# Altium schematic sheet LM-008.SchDoc(LM-008)
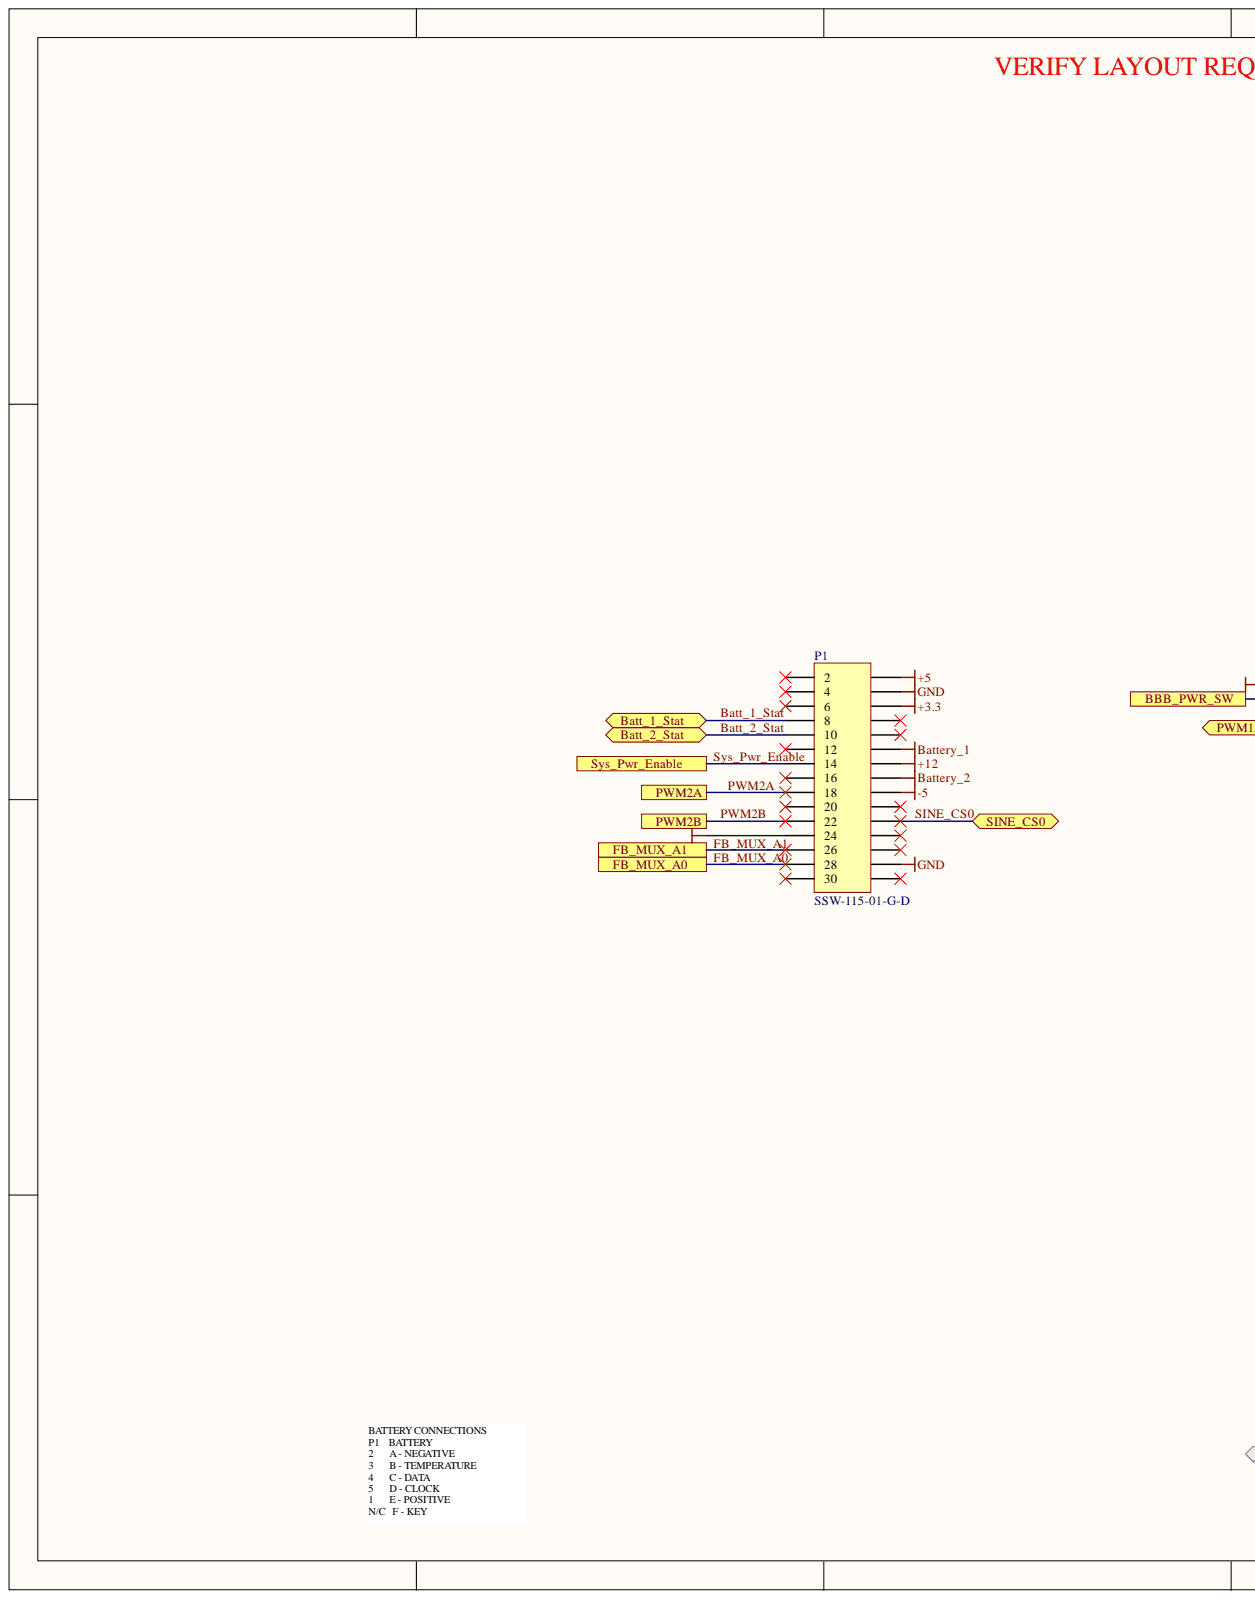
[diagram p0: LM-008.SchDoc, left]
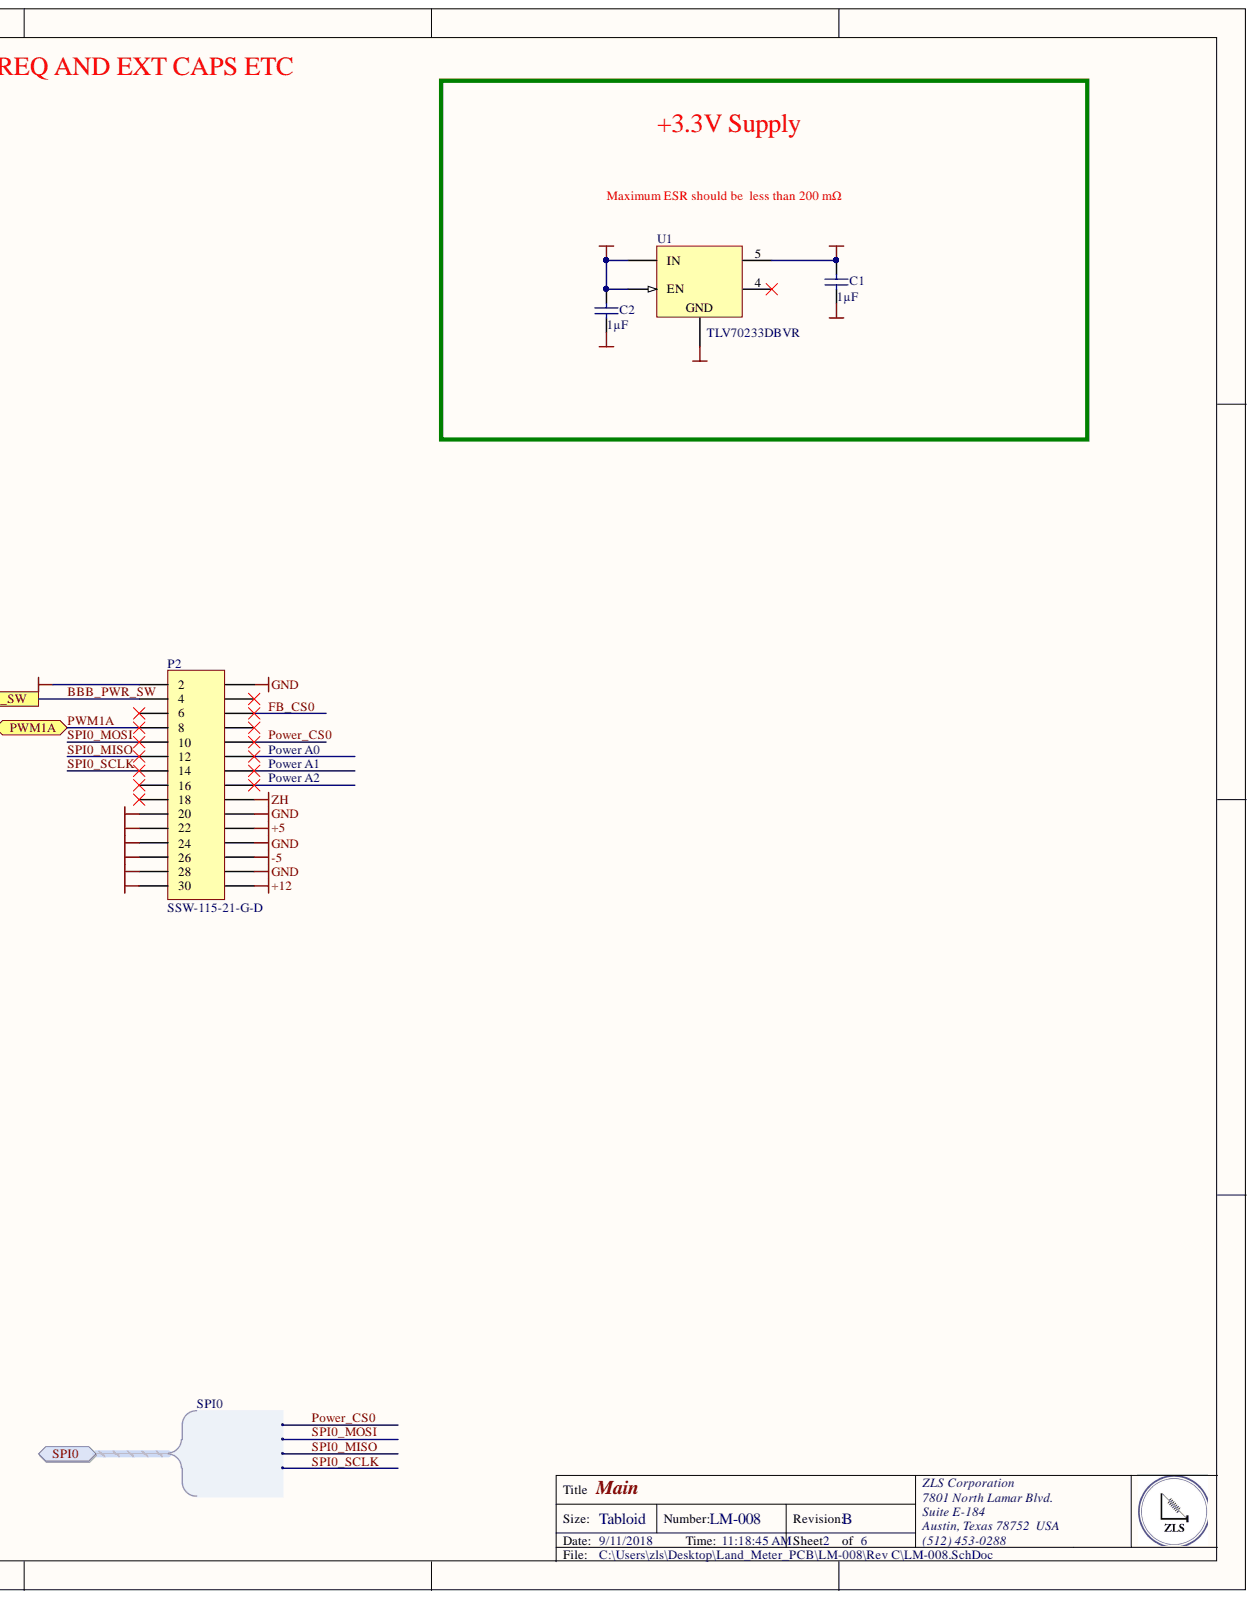
[diagram p1: LM-008.SchDoc, right]

## Parts
C1  Capacitance: 1µF  [CAPC1206(3216)130_N]  (hidden comment: CAP CER 1UF 50V X7R 1210)
C2  Capacitance: 1µF  [CAPC1206(3216)130_N]  (hidden comment: CAP CER 1UF 50V X7R 1210)
P1  SSW-115-01-G-D  [HDR2X15]
P2  SSW-115-21-G-D  [HDR2X15]
U1  TLV70233DBVR  [DBV0005A_N]

## Ports
Batt_1_Stat, Batt_2_Stat, BBB_PWR_SW, FB_MUX_A0, FB_MUX_A1, PWM1A, PWM2A, PWM2B, SINE_CS0, SPI0, SPI0.SPI0_CS0, SPI0.SPI0_MISO, SPI0.SPI0_MOSI, SPI0.SPI0_SCLK, Sys_Pwr_Enable

## Nets
+3.3: C1.2 P1.5 P2.26 U1.5
+5: C2.2 P1.1 P2.21 U1.1(IN) U1.3(EN)
+5 BBB: P2.2
+12: P1.13 P2.29 P2.30
-5: P1.17 P2.25
Battery_1: P1.11
Battery_2: P1.15
GND: C1.1 C2.1 P1.3 P1.24 P1.27 P2.1 P2.19 P2.20 P2.22 P2.23 P2.24 P2.27 P2.28 U1.2
ZH: P2.17
Batt_1_Stat: P1.8 ; port Batt_1_Stat
Batt_2_Stat: P1.10 ; port Batt_2_Stat
BBB_PWR_SW: P2.4 ; port BBB_PWR_SW
FB_CS0: P2.5
FB_MUX_A0: P1.28 ; port FB_MUX_A0
FB_MUX_A1: P1.26 ; port FB_MUX_A1
Power_CS0: P2.9 ; port SPI0
PWM1A: P2.8 ; port PWM1A
PWM2A: P1.18 ; port PWM2A
PWM2B: P1.22 ; port PWM2B
SINE_CS0: P1.21 ; port SINE_CS0
SPI0_MISO: P2.12 ; port SPI0
SPI0_MOSI: P2.10 ; port SPI0
SPI0_SCLK: P2.14 ; port SPI0
Sys_Pwr_Enable: P1.14 ; port Sys_Pwr_Enable

Not connected: P1 2 4 6 7 9 12 16 19 20 23 25 29 30; P2 3 6 7 11 13 15 16 18; U1 4

## Library data of the parts
C1 C2 = CMP-1037-04913-1: Manufacturer Part Number: GRM32RR71H105KA01L; Manufacturer: Murata Electronics North America; Tolerance: ±10%; Voltage - Rated: 50V; Thickness (Max): 0.079" (2.00mm); Temperature Coefficient: X7R; Supplier Part Number 1: 490-1863-6-ND; Supplier 1: Digi-Key; Stock 1: 66890; Size / Dimension: 0.126" L x 0.098" W (3.20mm x 2.50mm); RoHS: RoHS Compliant; Ratings: -; Pricing 1: 1=0.35, 10=0.242, 100=0.1449, 500=0.10868 (USD); Part Status: Active; Packaging: Digi-Reel®; Package / Case: 1210 (3225 Metric); Operating Temperature: -55°C ~ 125°C; Mounting Type: Surface Mount, MLCC; Library Name: Altium Content Vault; Lead Style: -; Lead Spacing: -; Height - Seated (Max): -; Features: -; Description: CAP CER 1UF 50V X7R 1210; ComponentLink1URL: http://search.murata.co.jp/Ceramy/image/img/A01X/G101/ENG/GRM32RR71H105KA01-01.pdf; ComponentLink1Description: http://search.murata.co.jp/Ceramy/image/img/A01X/G101/ENG/GRM32RR71H105KA01-01.pdf; Component Kind: Standard; Category: Capacitors; Applications: General Purpose
P1 = Header 15X2: CONN RCPT .100" 30POS DUAL GOLD; Manufacturer: Samtec Inc.; Style: Board to Board or Cable; Row Spacing - Mating: 0.100" (2.54mm); Number of Positions Loaded: All; Number of Positions: 30; Material Flammability Rating: UL94 V-0; Current Rating: 5.7A per Contact; Contact Length - Post: 0.104" (2.64mm); Connector Type: Receptacle; Voltage Rating: -; Termination: Solder; Supplier Part Number 1: SAM1208-15-ND; Supplier 1: Digi-Key; Stock 1: 0; Signal Integrity: Connector; RoHS: RoHS Compliant; Pricing 1: 1=5.69, 10=5.087, 100=4.189, 500=3.31126, 1000=2.8425, 5000=2.59316, 10000=2.49343 (USD); Pitch - Mating: 0.100" (2.54mm); Part Status: Active; Packaging: Bulk; Operating Temperature: -55°C ~ 125°C; Mounting Type: Through Hole; Mated Stacking Heights: -; Manufacturer Part Number: SSW-115-01-G-D; Library Name: Miscellaneous Connectors.IntLib; Insulation Material: Liquid Crystal Polymer (LCP); Insulation Height: 0.335" (8.51mm); Insulation Color: Black; Ingress Protection: -; Features: -; Fastening Type: Push-Pull; Contact Type: Forked; Contact Shape: Square; Contact Material: Phosphor Bronze; Contact Finish Thickness - Post: 3.00µin (0.076µm); Contact Finish Thickness - Mating: 20.0µin (0.51µm); Contact Finish - Post: Gold; Contact Finish - Mating: Gold; ComponentLink1URL: http://suddendocs.samtec.com/prints/ssw-1xx-xx-xxx-x-xx-xxx-xx-mkt.pdf; ComponentLink1Description: http://suddendocs.samtec.com/prints/ssw-1xx-xx-xxx-x-xx-xxx-xx-mkt.pdf; Component Kind: Standard; Category: Connectors, Interconnects; Applications: -
P2 = Header 15X2: Header, 15-Pin, Dual row; Manufacturer: Samtec Inc.; Style: Board to Board or Cable; Row Spacing - Mating: 0.100" (2.54mm); Number of Positions Loaded: All; Number of Positions: 30; Material Flammability Rating: UL94 V-0; Current Rating: 5.7A per Contact; Contact Length - Post: 0.104" (2.64mm); Connector Type: Receptacle; Voltage Rating: -; Termination: Solder; Supplier Part Number 1: SSW-115-21-G-D-ND; Supplier 1: Digi-Key; Stock 1: 0; Signal Integrity: Connector; RoHS: RoHS Compliant; Pricing 1: 1=4.78 (USD); Pitch - Mating: 0.100" (2.54mm); Part Status: Active; Packaging: Bulk; Operating Temperature: -55°C ~ 125°C; Mounting Type: Through Hole; Mated Stacking Heights: -; Manufacturer Part Number: SSW-115-21-G-D; Library Name: Miscellaneous Connectors.IntLib; Insulation Material: Liquid Crystal Polymer (LCP); Insulation Height: 0.335" (8.51mm); Insulation Color: Black; Ingress Protection: -; Features: -; Fastening Type: Push-Pull; Contact Type: Forked; Contact Shape: Square; Contact Material: Phosphor Bronze; Contact Finish Thickness - Post: 3.00µin (0.076µm); Contact Finish Thickness - Mating: 20.0µin (0.51µm); Contact Finish - Post: Gold; Contact Finish - Mating: Gold; Component Kind: Standard; Category: Connectors, Interconnects; Applications: -
U1 = CMP-0394-00528-2: IC REG LINEAR 3.3V 300MA SOT23-5; Manufacturer: Texas Instruments; Voltage Dropout (Max): 0.38V @ 300mA; Voltage - Input (Max): 5.5V; PSRR: 68dB (1kHz); Protection Features: Over Current, Over Temperature, Reverse Polarity, Under Voltage Lockout (UVLO); Output Type: Fixed; Output Configuration: Positive; Control Features: Enable; Voltage - Output (Min/Fixed): 3.3V; Voltage - Output (Max): -; Supplier Part Number 1: 296-32415-1-ND; Supplier Device Package: SOT-23-5; Supplier 1: Digi-Key; Stock 1: 65852; RoHS: RoHS Compliant; Pricing 1: 1=0.51, 10=0.44, 100=0.3288, 500=0.25832, 1000=0.19961 (USD); Part Status: Active; Packaging: Cut Tape (CT); Package / Case: SC-74A, SOT-753; Operating Temperature: -40°C ~ 125°C; Mounting Type: Surface Mount; Manufacturer Part Number: TLV70233DBVR; Library Name: Altium Content Vault; Current - Output: 300mA; ComponentLink1URL: http://www.ti.com/lit/ds/symlink/tlv702.pdf; ComponentLink1Description: http://www.ti.com/lit/ds/symlink/tlv702.pdf; Component Kind: Standard; Category: Integrated Circuits (ICs); Base Part Number: TLV70233
